# Altium schematic sheet ESP32.SchDoc(ESP32)
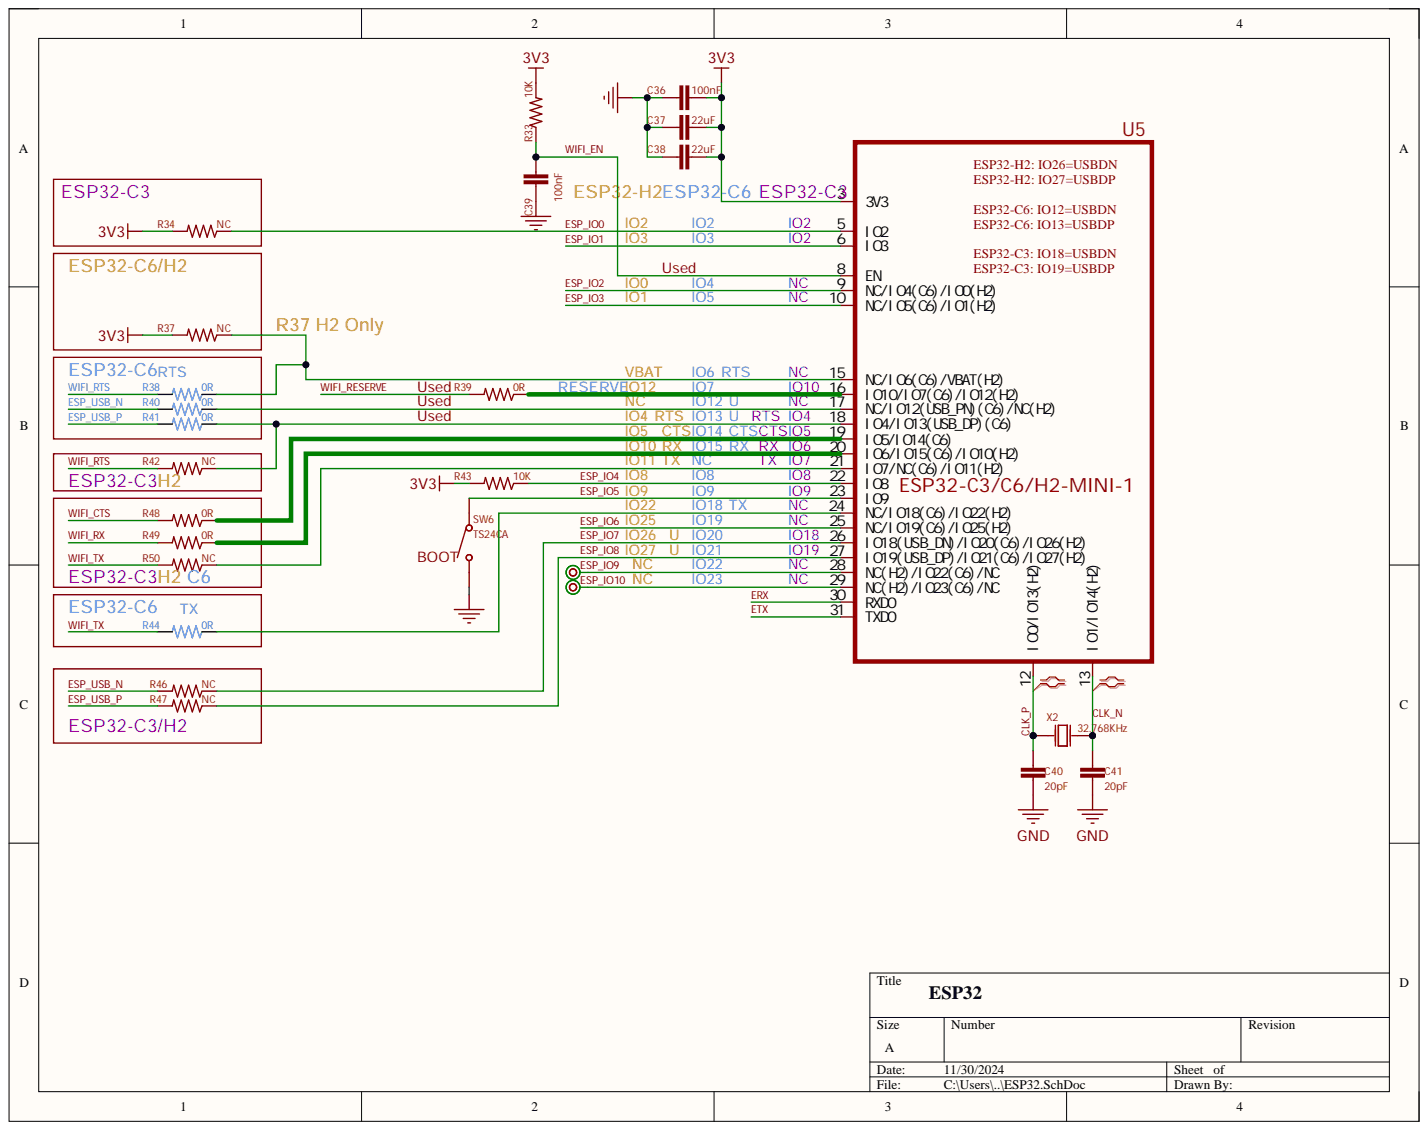
[diagram p0: ESP32.SchDoc]

## Parts
C36  100nF  [C0402]
C37  22uF  [C0603]
C38  22uF  [C0603]
C39  100nF  [C0402]
C40  20pF  [C0402]
C41  20pF  [C0402]
R33  10K  [R0402]
R34  NC  [R0402]
R37  NC  [R0402]
R38  0R  [R0402]
R39  0R  [R0402]
R40  0R  [R0402]
R41  0R  [R0402]
R42  NC  [R0402]
R43  10K  [R0402]
R44  0R  [R0402]
R46  NC  [R0402]
R47  NC  [R0402]
R48  0R  [R0402]
R49  0R  [R0402]
R50  NC  [R0402]
SW6  TS24CA  [SW-SMD_TS24CA]
TP11  Fiducial mark 1mm with 2mm solder  [TP-1.00MM]  (value not drawn)  (no BOM)
TP12  Fiducial mark 1mm with 2mm solder  [TP-1.00MM]  (value not drawn)  (no BOM)
U5  ESP32-C3/C6/H2  [WIFIM-SMD_ESP32-C3-MINI-MINI-1U]
X2  32.768KHz  [FC-12M_L2.0-W1.2]

## Nets
3V3: C36.2 C37.2 C38.2 R33.2 R34.2 R37.2 R43.2 U5.3(3V3)
GND: C36.1 C37.1 C38.1 C39.1 C40.1 C41.1 SW6.1 SW6.2 SW6.4 U5.1 U5.2 U5.11 U5.14 U5.36 U5.37 U5.38 U5.39 U5.40 U5.41 U5.42 U5.43 U5.44 U5.45 U5.46 U5.47 U5.48 U5.49 U5.50 U5.51 U5.52 U5.53
CLK_N: C41.2 U5.13(IO1/IO14(H2)) X2.1
CLK_P: C40.2 U5.12(IO0/IO13(H2)) X2.2
ERX: U5.30(RXD0)
ESP_IO0: R34.1 U5.5(IO2)
ESP_IO1: U5.6(IO3)
ESP_IO2: U5.9(NC/IO4(C6)/IO0(H2))
ESP_IO3: U5.10(NC/IO5(C6)/IO1(H2))
ESP_IO4: R43.1 U5.22(IO8)
ESP_IO5: SW6.3 U5.23(IO9)
ESP_IO6: U5.25(NC/IO19(C6)/IO25(H2))
ESP_IO7: R46.1 U5.26(IO18(USB_DN)/IO20(C6)/IO26(H2))
ESP_IO8: R47.1 U5.27(IO19(USB_DP)/IO21(C6)/IO27(H2))
ESP_USB_N: R40.2 R46.2
ESP_USB_P: R41.2 R47.2
ETX: U5.31(TXD0)
WIFI_CTS: R48.2
WIFI_EN: C39.2 R33.1 U5.8(EN)
WIFI_RESERVE: R39.2
WIFI_RTS: R38.2 R42.2
WIFI_RX: R49.2
WIFI_TX: R44.2 R50.2
NetR37_1: R37.1 R38.1 U5.15(NC/IO6(C6)/VBAT(H2))
NetR39_1: R39.1 U5.16(IO10/IO7(C6)/IO12(H2))
NetR40_1: R40.1 U5.17(NC/IO12(USB_PN)(C6)/NC(H2))
NetR41_1: R41.1 R42.1 U5.18(IO4/IO13(USB_DP)(C6))
NetR44_1: R44.1 U5.24(NC/IO18(C6)/IO22(H2))
NetR48_1: R48.1 U5.19(IO5/IO14(C6))
NetR49_1: R49.1 U5.20(IO6/IO15(C6)/IO10(H2))
NetR50_1: R50.1 U5.21(IO7/NC(C6)/IO11(H2))
NetTP11_1: TP11.1 U5.28(NC(H2)/IO22(C6)/NC)
NetTP12_1: TP12.1 U5.29(NC(H2)/IO23(C6)/NC)
ESP_IO9:
ESP_IO10:

## Library data of the parts
C36-C41 = C0201: 0201  贴片电容; Component Kind: Standard; Library Name: 常用.SCHLIB
R33 R34 R37-R44 R46-R50 = R0402: 0402 贴片电阻; Component Kind: Standard; Library Name: 常用.SCHLIB
SW6 = TS24CA: 触点电流:50mA;额定电压(DC):12V;电路结构:单刀单掷;安装方式:卧贴;按钮形状:矩形按钮;带灯:-;开关长度:4.55mm;开关宽度:1.8mm;开关高度:3.5mm;; Manufacturer: SHOU HAN(首韩); Actuator Style: 矩形按钮; Add into BOM: yes; Circuit: 单刀单掷; Contact Current: 50mA; Switch Height: 3.5mm; Switch Length: 4.55mm; Switch Width: 1.8mm; Voltage Rating (DC): 12V; Component Kind: Standard; ComponentLink1Description: 立创链接; ComponentLink1URL: https://item.szlcsc.com/372554.html; Convert to PCB: yes; Datasheet: https://atta.szlcsc.com/upload/public/pdf/source/20190521/C393942_591A9E318887EFA4274D34029981AD99.pdf; Device: TS24CA; JLCPCB Part Class: Extended Part; LCSC Part Name: 2*4*3.5半包小贝贝TS-018小侧按键m165蓝牙耳机轻触开关; Library Name: 常用.SCHLIB; Manufacturer Part: TS24CA; Mounting Style: 卧贴; PCB3D: SW-SMD_TS24CA; Supplier: LCSC; Supplier Footprint: SMD; Supplier Part: C393942; Symbol: TS24CA; With Lamp: -
TP11 TP12 = UT0024-A.6: Description: Fiducial mark 1mm with 2mm solder; Library Name: UNO R4 WiFi.SCHLIB
U5 = ESP32-C3/C6/H2: 模块选贴ESP32-C3-MINI-1U-H4; Manufacturer: ESPRESSIF(乐鑫); Add into BOM: yes; Device: ESP32-C3-MINI-1-N4; Component Kind: Standard; Convert to PCB: yes; Datasheet: https://atta.szlcsc.com/upload/public/pdf/source/20210720/C2838502_820C448AE0C4DF1D4546A4A5DCA77C73.pdf; JLCPCB Part Class: Extended Part; LCSC Part Name: ESP32-C3-MINI-1-N4; Library Name: RP2040.SCHLIB; Manufacturer Part: ESP32-C3-MINI-1-N4; PCB3D: WIFIM-SMD_ESP32-C3-MINI-1; Supplier: LCSC; Supplier Footprint: SMD,13.2x16.6mm; Supplier Part: C2838502; Symbol: ESP32-C3-MINI-1-N4
X2 = FC-12M32.768KHZ7PF20PPM: 32.768kHz ±20ppm 7pF SMD2012-2P; Component Kind: Standard; ComponentLink1Description: 立创链接; ComponentLink1URL: https://item.szlcsc.com/100221.html; Library Name: 常用.SCHLIB
